# Altium schematic sheet STM32_Core.SchDoc(U_STM32_Core)
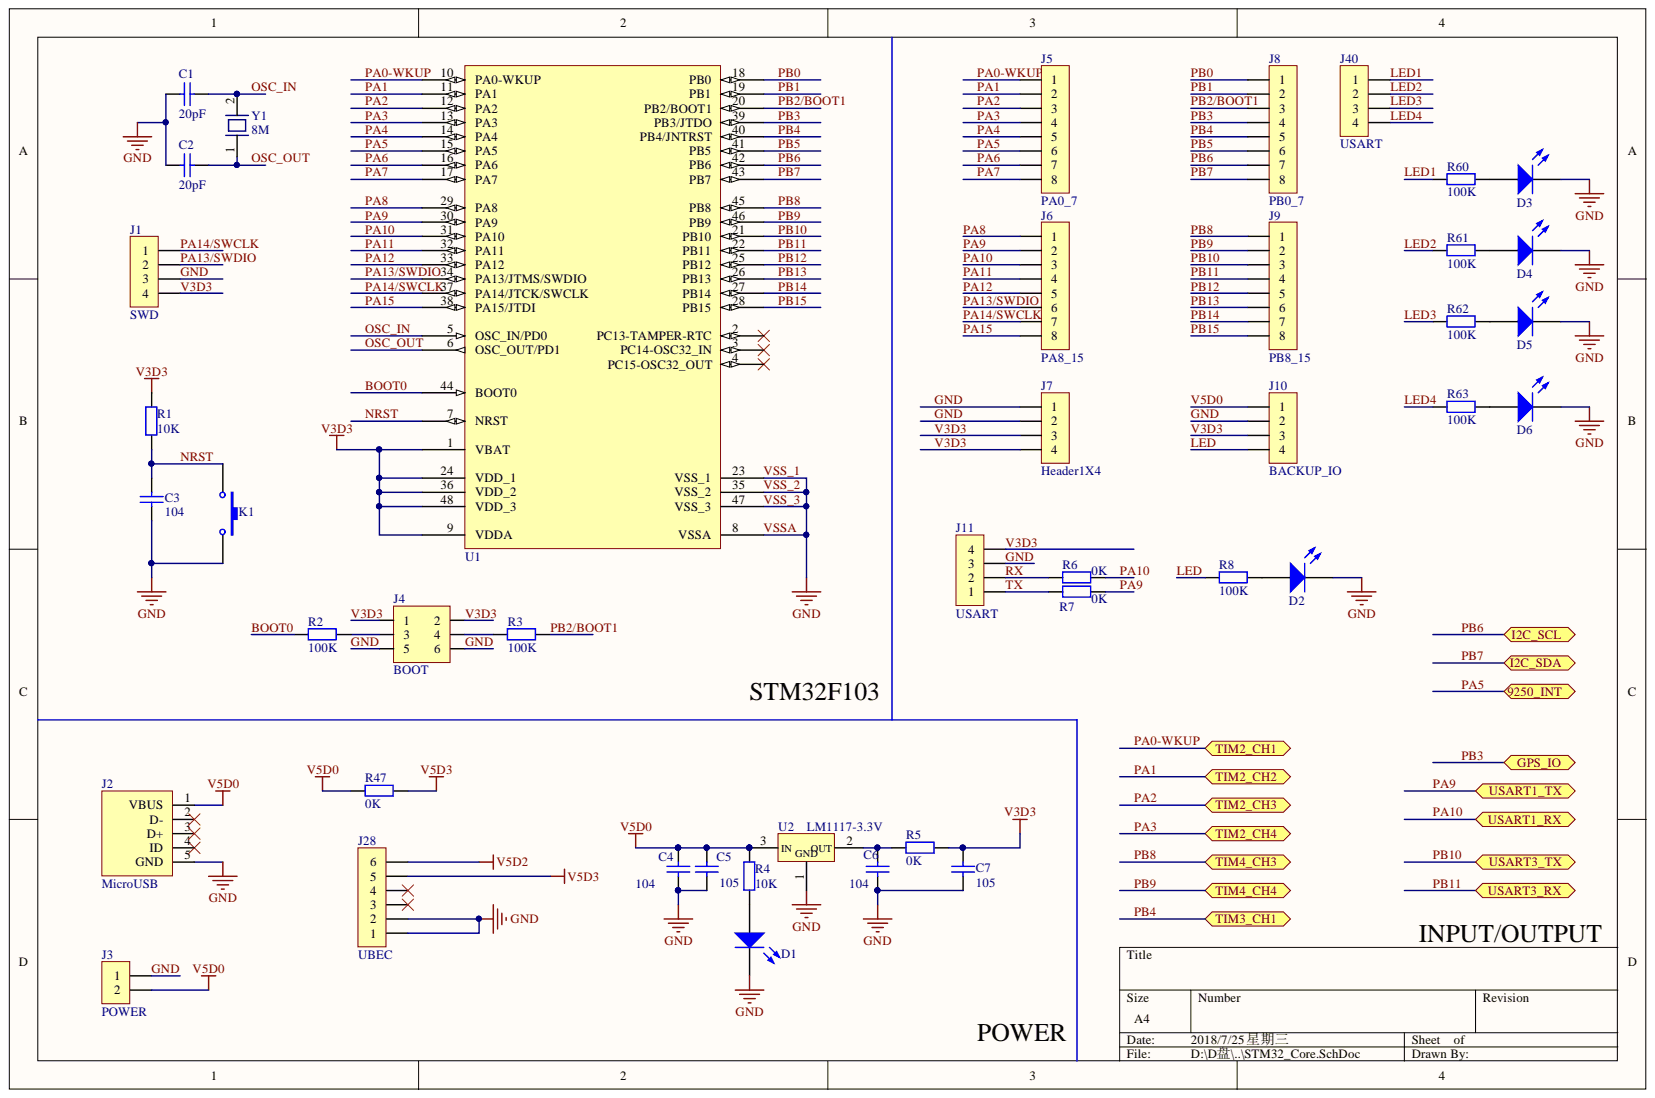
[diagram p0: STM32_Core.SchDoc]

## Parts
C1  value 20pF  [1206]  (hidden comment: Cap)
C2  value 20pF  [1206]  (hidden comment: Cap)
C3  value 104  [1206]  (hidden comment: Cap)
C4  value 104  [1206]  (hidden comment: Cap)
C5  value 105  [1206]  (hidden comment: Cap)
C6  value 104  [1206]  (hidden comment: Cap)
C7  value 105  [1206]  (hidden comment: Cap)
D1  D*  [SIP-2.54-1x2]
D2  D*  [SIP-2.54-1x2]
D3  D*  [SIP-2.54-1x2]
D4  D*  [SIP-2.54-1x2]
D5  D*  [SIP-2.54-1x2]
D6  D*  [SIP-2.54-1x2]
J1  SWD  [SIP-2.54-1x4]
J2  MicroUSB  [MicroUSB]
J3  POWER  [SIP-2.54-1x2]
J4  BOOT  [SIP-2.54-2x3]
J5  PA0_7  [SIP-2.54-1x8]
J6  PA8_15  [SIP-2.54-1x8]
J7  Header1X4  [SIP-2.54-1x4]
J8  PB0_7  [SIP-2.54-1x8]
J9  PB8_15  [SIP-2.54-1x8]
J10  BACKUP_IO  [SIP-2.54-1x4]
J11  USART  [SIP-2.54-1x4]
J28  UBEC  [1.25-AWT]
J40  USART  [SIP-2.54-1x4]
K1  Button  [Button]  (value not drawn)
R1  R*  value 10K  [1206]
R2  R*  value 100K  [1206]
R3  R*  value 100K  [1206]
R4  R*  value 10K  [1206]
R5  R*  value 0K  [1206]
R6  R*  value 0K  [1206]
R7  R*  value 0K  [1206]
R8  R*  value 100K  [1206]
R47  R*  value 0K  [0603]
R60  R*  value 100K  [1206]
R61  R*  value 100K  [1206]
R62  R*  value 100K  [1206]
R63  R*  value 100K  [1206]
U1  U*  [QFP48_L]
U2  LM1117-3.3V  [SOT223L]
Y1  8M  [XTAL-1]

## Ports
9250_INT, GPS_IO, I2C_SCL, I2C_SDA, TIM2_CH1, TIM2_CH2, TIM2_CH3, TIM2_CH4, TIM3_CH1, TIM4_CH3, TIM4_CH4, USART1_RX, USART1_TX, USART3_RX, USART3_TX

## Nets
V5D2: J28.6
V5D3: J28.5 R47.2
BOOT0: R2.1 U1.44(BOOT0)
GND: C1.1 C2.2 C3.1 C4.1 C5.1 C6.1 C7.1 D1.2 D2.2 D3.2 D4.2 D5.2 D6.2 J1.3 J2.5(GND) J3.1 J4.5 J4.6 J7.1 J7.2 J10.2 J11.3 J28.1 J28.2 K1.2 U1.8(VSSA) U1.23(VSS_1) U1.35(VSS_2) U1.47(VSS_3) U2.1
LED: J10.4 R8.1
LED1: J40.1 R60.1
LED2: J40.2 R61.1
LED3: J40.3 R62.1
LED4: J40.4 R63.1
NRST: C3.2 K1.1 R1.1 U1.7(NRST)
OSC_IN: C1.2 U1.5(OSC_IN/PD0) Y1.2
OSC_OUT: C2.1 U1.6(OSC_OUT/PD1) Y1.1
PA0-WKUP: J5.1 U1.10(PA0-WKUP) ; port TIM2_CH1
PA1: J5.2 U1.11(PA1) ; port TIM2_CH2
PA2: J5.3 U1.12(PA2) ; port TIM2_CH3
PA3: J5.4 U1.13(PA3) ; port TIM2_CH4
PA4: J5.5 U1.14(PA4)
PA5: J5.6 U1.15(PA5) ; port 9250_INT
PA6: J5.7 U1.16(PA6)
PA7: J5.8 U1.17(PA7)
PA8: J6.1 U1.29(PA8)
PA9: J6.2 R7.2 U1.30(PA9) ; port USART1_TX
PA10: J6.3 R6.2 U1.31(PA10) ; port USART1_RX
PA11: J6.4 U1.32(PA11)
PA12: J6.5 U1.33(PA12)
PA13/SWDIO: J1.2 J6.6 U1.34(PA13/JTMS/SWDIO)
PA14/SWCLK: J1.1 J6.7 U1.37(PA14/JTCK/SWCLK)
PA15: J6.8 U1.38(PA15/JTDI)
PB0: J8.1 U1.18(PB0)
PB1: J8.2 U1.19(PB1)
PB2/BOOT1: J8.3 R3.2 U1.20(PB2/BOOT1)
PB3: J8.4 U1.39(PB3/JTDO) ; port GPS_IO
PB4: J8.5 U1.40(PB4/JNTRST) ; port TIM3_CH1
PB5: J8.6 U1.41(PB5)
PB6: J8.7 U1.42(PB6) ; port I2C_SCL
PB7: J8.8 U1.43(PB7) ; port I2C_SDA
PB8: J9.1 U1.45(PB8) ; port TIM4_CH3
PB9: J9.2 U1.46(PB9) ; port TIM4_CH4
PB10: J9.3 U1.21(PB10) ; port USART3_TX
PB11: J9.4 U1.22(PB11) ; port USART3_RX
PB12: J9.5 U1.25(PB12)
PB13: J9.6 U1.26(PB13)
PB14: J9.7 U1.27(PB14)
PB15: J9.8 U1.28(PB15)
RX: J11.2 R6.1
TX: J11.1 R7.1
V3D3: C7.2 J1.4 J4.1 J4.2 J7.3 J7.4 J10.3 J11.4 R1.2 R5.2 U1.1(VBAT) U1.9(VDDA) U1.24(VDD_1) U1.36(VDD_2) U1.48(VDD_3)
V5D0: C4.2 C5.2 J2.1(VBUS) J3.2 J10.1 R4.1 R47.1 U2.3
NetC6_2: C6.2 R5.1 U2.2
NetD1_1: D1.1 R4.2
NetD2_1: D2.1 R8.2
NetD3_1: D3.1 R60.2
NetD4_1: D4.1 R61.2
NetD5_1: D5.1 R62.2
NetD6_1: D6.1 R63.2
NetJ4_3: J4.3 R2.2
NetJ4_4: J4.4 R3.1

Not connected: J2 2(D-) 3(D+) 4(ID); J28 3 4; U1 2(PC13-TAMPER-RTC) 3(PC14-OSC32_IN) 4(PC15-OSC32_OUT)

## Library data of the parts
D1-D6 = LED: Component Kind: Standard
  D1: Library Name: relay_sensor.SchLib
  D2-D6: Library Name: MyIntergrateLib.IntLib
J1 J10 J11 J40 = Header1X4: Component Kind: Standard
  J1 J11 J40: Library Name: relay_sensor.SchLib
  J10: Library Name: MyIntergrateLib.IntLib
J3 = Header1X2: Library Name: relay_sensor.SchLib; Component Kind: Standard
J4 = Header2X3: Library Name: relay_sensor.SchLib; Description: Header2X3; Component Kind: Standard
J5 J6 J8 J9 = Header1x8: Library Name: relay_sensor.SchLib; Component Kind: Standard
J28 = Header1x6: Component Kind: Standard; Library Name: MyIntergrateLib.IntLib
R1-R8 R47 R60-R63 = Res: Component Kind: Standard
  R1-R4: Library Name: relay_sensor.SchLib
  R5-R8 R47 R60-R63: Library Name: MyIntergrateLib.IntLib
U1 = LQFP48: LQFP48 7x7mm; Component Kind: Standard; Library Name: MyIntergrateLib.IntLib
Y1 = XTAL-2: Component Kind: Standard; Library Name: MyIntergrateLib.IntLib
C1-C7 = Cap: Library Name: relay_sensor.SchLib; Component Kind: Standard
J2 = MicroUSB: Library Name: relay_sensor.SchLib; Component Kind: Standard
J7 = Header1X4: Component Kind: Standard; Library Name: MyIntergrateLib.IntLib
K1 = Button: Library Name: relay_sensor.SchLib; Component Kind: Standard
U2 = LM1117-3.3V: Library Name: relay_sensor.SchLib; Component Kind: Standard
